# Altium schematic sheet PD_Controller_TI.SchDoc(PD_Controller_TI)
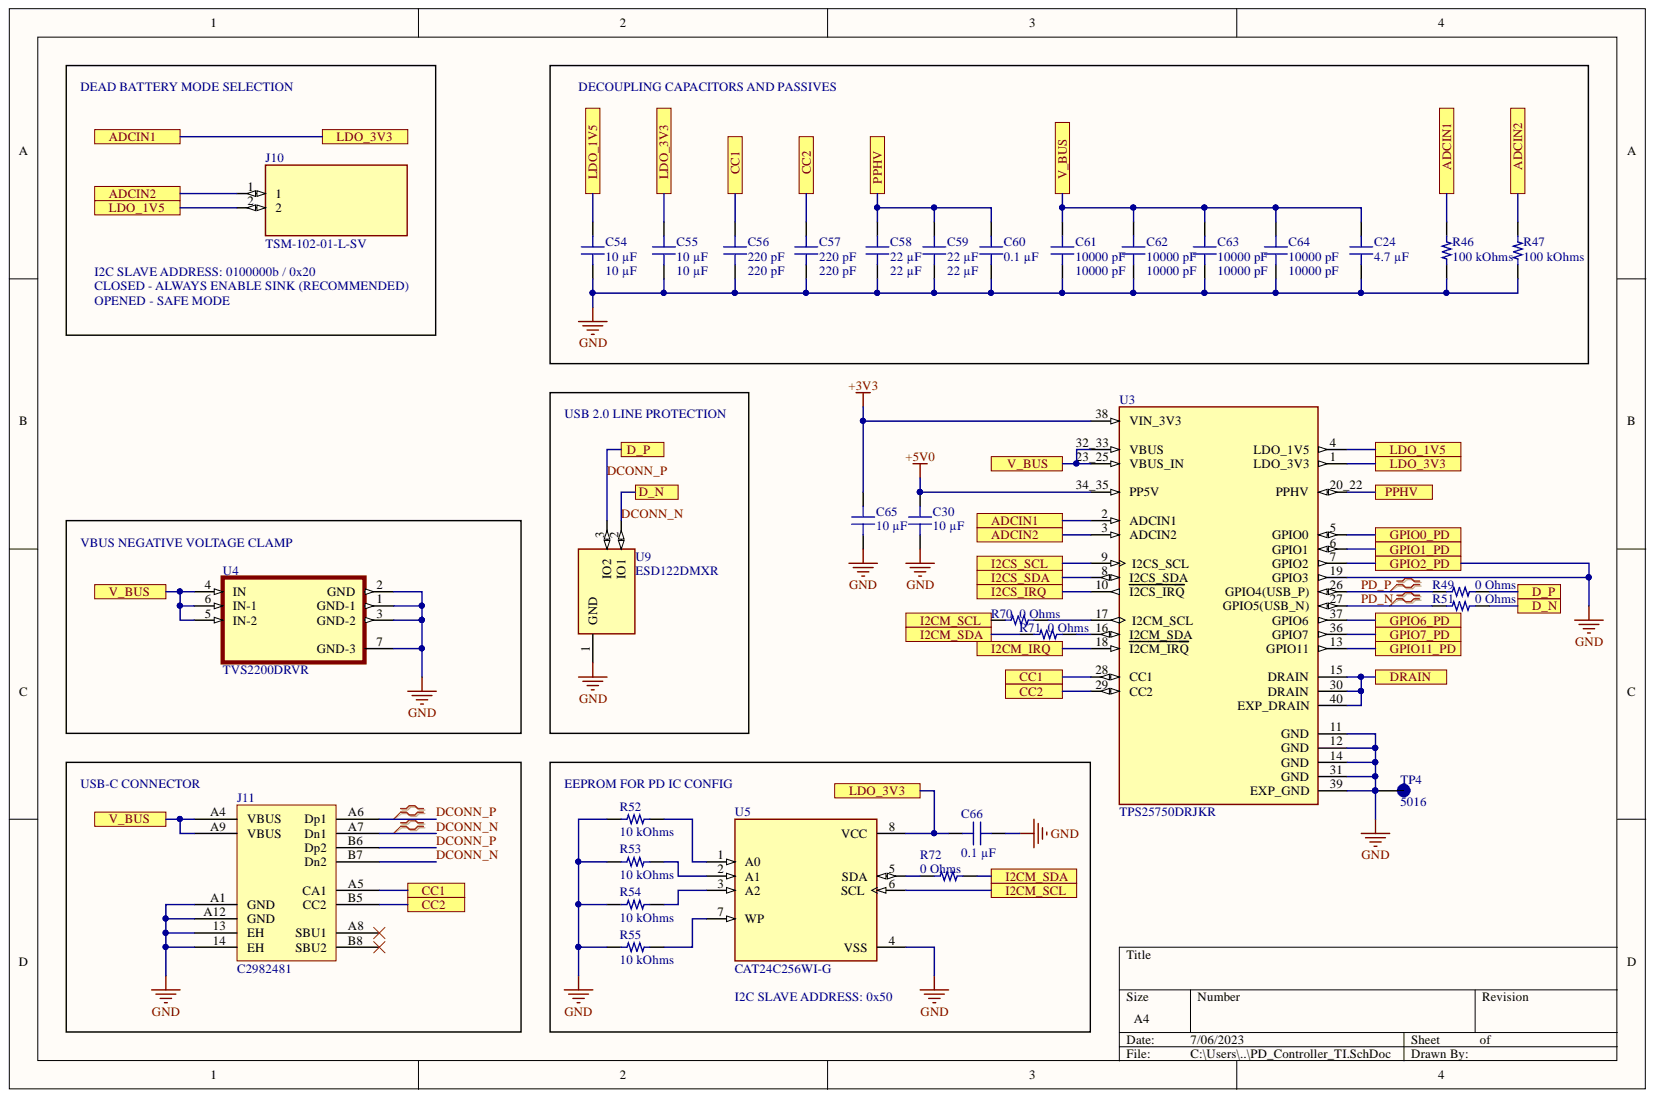
[diagram p0: PD_Controller_TI.SchDoc]

## Parts
C24  4.7 µF  [CAP 0603_1608]
C30  10 µF  [CAP 0402_1005]
C54  10 µF  [CAP 0402_1005]
C55  10 µF  [CAP 0402_1005]
C56  220 pF  [CAP 0402_1005]
C57  220 pF  [CAP 0402_1005]
C58  22 µF  [CAP 0805_2012]
C59  22 µF  [CAP 0805_2012]
C60  0.1 µF  [CAP 0402_1005]
C61  10000 pF  [CAP 0402_1005]
C62  10000 pF  [CAP 0402_1005]
C63  10000 pF  [CAP 0402_1005]
C64  10000 pF  [CAP 0402_1005]
C65  10 µF  [CAP 0402_1005]
C66  0.1 µF  [CAP 0402_1005]
J10  TSM-102-01-L-SV  [TSM-102-01-L-SV]
J11  C2982481  [GT-USB-7079A]
R46  100 kOhms  [RES 0402_1005]
R47  100 kOhms  [RES 0402_1005]
R49  0 Ohms  [RES 0402_1005]
R51  0 Ohms  [RES 0402_1005]
R52  10 kOhms  [RES 0402_1005]
R53  10 kOhms  [RES 0402_1005]
R54  10 kOhms  [RES 0402_1005]
R55  10 kOhms  [RES 0402_1005]
R70  0 Ohms  [RES 0402_1005]
R71  0 Ohms  [RES 0402_1005]
R72  0 Ohms  [RES 0402_1005]
TP4  5016  [KEYSTONE_5016]
U3  TPS25750DRJKR  [IC_TPS25750DRJKR]
U4  TVS2200DRVR  [SON65P200X200X80-7N]
U5  CAT24C256WI-G  [SOIC127P600X175-8N]
U9  ESD122DMXR  [IC_ESD122DMXR]

## Ports
ADCIN1, ADCIN2, CC1, CC2, D_N, D_P, DRAIN, GPIO0_PD, GPIO1_PD, GPIO2_PD, GPIO6_PD, GPIO7_PD, GPIO11_PD, I2CM_IRQ, I2CM_SCL, I2CM_SDA, I2CS_IRQ, I2CS_SCL, I2CS_SDA, LDO_1V5, LDO_3V3, PPHV, V_BUS

## Nets
+3V3: C65.1 U3.38(VIN_3V3)
+5V0: C30.1 U3.34_35(PP5V)
ADCIN1: C55.2 C66.2 R46.2 U3.1(LDO_3V3) U3.2(ADCIN1) U5.8(VCC) ; port ADCIN1, port LDO_3V3
ADCIN2: J10.1 R47.2 U3.3(ADCIN2) ; port ADCIN2
CC1: C56.1 J11.A5(CA1) U3.28(CC1) ; port CC1
CC2: C57.1 J11.B5(CC2) U3.29(CC2) ; port CC2
DCONN_N: J11.A7(Dn1) J11.B7(Dn2) R51.2 U9.2 ; port D_N
DCONN_P: J11.A6(Dp1) J11.B6(Dp2) R49.2 U9.3 ; port D_P
DRAIN: U3.15(DRAIN) U3.30(DRAIN) U3.40(EXP_DRAIN) ; port DRAIN
GND: C24.1 C30.2 C54.1 C55.1 C56.2 C57.2 C58.1 C59.1 C60.2 C61.1 C62.1 C63.1 C64.1 C65.2 C66.1 J11.13(EH) J11.14(EH) J11.A1(GND) J11.A12(GND) R46.1 R47.1 R52.2 R53.2 R54.2 R55.2 TP4.TP U3.7(GPIO2) U3.11(GND) U3.12(GND) U3.14(GND) U3.19(GPIO3) U3.31(GND) U3.39(EXP_GND) U4.1(GND-1) U4.2(GND) U4.3(GND-2) U4.7(GND-3) U5.4(VSS) U9.1 ; port GPIO2_PD
GPIO0_PD: U3.5(GPIO0) ; port GPIO0_PD
GPIO1_PD: U3.6(GPIO1) ; port GPIO1_PD
GPIO6_PD: U3.37(GPIO6) ; port GPIO6_PD
GPIO7_PD: U3.36(GPIO7) ; port GPIO7_PD
GPIO11_PD: U3.13(GPIO11) ; port GPIO11_PD
I2CM_IRQ: U3.18(I2CM_IRQ) ; port I2CM_IRQ
I2CM_SCL: R70.1 U5.6(SCL) ; port I2CM_SCL
I2CM_SDA: R71.1 R72.2 ; port I2CM_SDA
I2CS_IRQ: U3.10(I2CS_IRQ) ; port I2CS_IRQ
I2CS_SCL: U3.9(I2CS_SCL) ; port I2CS_SCL
I2CS_SDA: U3.8(I2CS_SDA) ; port I2CS_SDA
LDO_1V5: C54.2 J10.2 U3.4(LDO_1V5) ; port LDO_1V5
PD_N: R51.1 U3.27(GPIO5(USB_N))
PD_P: R49.1 U3.26(GPIO4(USB_P))
PPHV: C58.2 C59.2 C60.1 U3.20_22(PPHV) ; port PPHV
V_BUS: C24.2 C61.2 C62.2 C63.2 C64.2 J11.A4(VBUS) J11.A9(VBUS) U3.23_25(VBUS_IN) U3.32_33(VBUS) U4.4(IN) U4.5(IN-2) U4.6(IN-1) ; port V_BUS
NetR52_1: R52.1 U5.1(A0)
NetR53_1: R53.1 U5.2(A1)
NetR54_1: R54.1 U5.3(A2)
NetR55_1: R55.1 U5.7(WP)
NetR70_2: R70.2 U3.17(I2CM_SCL)
NetR71_2: R71.2 U3.16(I2CM_SDA)
NetR72_1: R72.1 U5.5(SDA)

Not connected: J11 A8(SBU1) B8(SBU2)

## Library data of the parts
C24 = C1608X5R1V475K080AC: CAP CER 4.7UF 35V X5R 0603; manufacturer: TDK Corporation; Tolerance: ±10%; Database Table Name: Capacitors - Ceramic - 0603; Voltage - Rated: 35V; Value: 4.7 µF; Thickness (Max): 0.039" (1.00mm); Temperature Coefficient: X5R; Supplier Part Number 1: 445-9064-1-ND; Supplier 1: DigiKey; Size / Dimension: 0.063" L x 0.031" W (1.60mm x 0.80mm); series: C; price: 0.08; Part Status: Active; Part Number: C1608X5R1V475K080AC; partid: 2627; packaging: TapeAndReel; Package / Case: 0603 (1608 Metric); Operating Temperature: -55°C ~ 85°C; Mounting Type: Surface Mount, MLCC; Minimum Order: 1; Lifecycle Status: Active; Library Name: Celestial Altium Library - altium_library.DbLib; lastupdated: 9/05/2023 7:14:01 PM; Features: Low ESL; Device Package: 0603 (1608 Metric); componentlink2url: https://www.digikey.com/en/products/detail/tdk-corporation/C1608X5R1V475K080AC/3648577; componentlink2description: DigiKey Link; componentlink1url: https://product.tdk.com/info/en/catalog/datasheets/mlcc_commercial_general_en.pdf?ref_disty=digikey; componentlink1description: Datasheet; Component Kind: Standard; Capacitance: 4.7 µF; Applications: General Purpose
C30 C54 C55 C65 = CL05A106MP8NUB8: CAP CER 10UF 10V X5R 0402; manufacturer: Samsung Electro-Mechanics; Tolerance: ±20%; Database Table Name: Capacitors - Ceramic - 0402; Voltage - Rated: 10V; Value: 10 µF; Thickness (Max): 0.035" (0.90mm); Temperature Coefficient: X5R; Supplier Part Number 1: 1276-6830-1-ND; Supplier 1: DigiKey; Size / Dimension: 0.039" L x 0.020" W (1.00mm x 0.50mm); series: CL; price: 0.06; Part Status: Active; Part Number: CL05A106MP8NUB8; partid: 1758; packaging: TapeAndReel; Package / Case: 0402 (1005 Metric); Operating Temperature: -55°C ~ 85°C; Mounting Type: Surface Mount, MLCC; Minimum Order: 1; Lifecycle Status: Active; Library Name: Celestial Altium Library - altium_library.DbLib; lastupdated: 26/04/2023 7:02:37 PM; Device Package: 0402 (1005 Metric); componentlink2url: https://www.digikey.com/en/products/detail/samsung-electro-mechanics/CL05A106MP8NUB8/5961314; componentlink2description: DigiKey Link; componentlink1url: http://www.samsungsem.com/kr/support/product-search/mlcc/CL05A106MP8NUB8.jsp; componentlink1description: Datasheet; Component Kind: Standard; Capacitance: 10 µF; Applications: Acoustic Noise Reduction
C56 C57 = 885012205021: CAP CER 220PF 16V X7R 0402; manufacturer: Würth Elektronik; Tolerance: ±10%; Database Table Name: Capacitors - Ceramic - 0402; Voltage - Rated: 16V; Value: 220 pF; Thickness (Max): 0.022" (0.55mm); Temperature Coefficient: X7R; Supplier Part Number 1: 732-7516-1-ND; Supplier 1: DigiKey; Size / Dimension: 0.039" L x 0.020" W (1.00mm x 0.50mm); series: WCAP-CSGP; price: 0.01; Part Status: Active; Part Number: 885012205021; partid: 2015; packaging: TapeAndReel; Package / Case: 0402 (1005 Metric); Operating Temperature: -55°C ~ 125°C; Mounting Type: Surface Mount, MLCC; Minimum Order: 1; Lifecycle Status: Active; Library Name: Celestial Altium Library - altium_library.DbLib; lastupdated: 26/04/2023 7:02:36 PM; Device Package: 0402 (1005 Metric); componentlink2url: https://www.digikey.com/en/products/detail/würth-elektronik/885012205021/5453371; componentlink2description: DigiKey Link; componentlink1url: https://katalog.we-online.de/pbs/datasheet/885012205021.pdf; componentlink1description: Datasheet; Component Kind: Standard; Capacitance: 220 pF; Applications: General Purpose
C58 C59 = C2012X5R1V226M125AC: CAP CER 22UF 35V X5R 0805; manufacturer: TDK Corporation; Tolerance: ±20%; Database Table Name: Capacitors - Ceramic - 0805; Voltage - Rated: 35V; Value: 22 µF; Thickness (Max): 0.057" (1.45mm); Temperature Coefficient: X5R; Supplier Part Number 1: 445-14428-1-ND; Supplier 1: DigiKey; Size / Dimension: 0.079" L x 0.049" W (2.00mm x 1.25mm); series: C; price: 0.28; Part Status: Active; Part Number: C2012X5R1V226M125AC; partid: 45365; packaging: TapeAndReel; Package / Case: 0805 (2012 Metric); Operating Temperature: -55°C ~ 85°C; Mounting Type: Surface Mount, MLCC; Minimum Order: 1; Lifecycle Status: Active; Library Name: Celestial Altium Library - altium_library.DbLib; lastupdated: 26/04/2023 7:09:36 PM; Features: Low ESL; Device Package: 0805 (2012 Metric); componentlink2url: https://www.digikey.com/en/products/detail/tdk-corporation/C2012X5R1V226M125AC/3951664; componentlink2description: DigiKey Link; componentlink1url: https://product.tdk.com/info/en/catalog/datasheets/mlcc_commercial_general_en.pdf?ref_disty=digikey; componentlink1description: Datasheet; Component Kind: Standard; Capacitance: 22 µF; Applications: General Purpose
C60 C66 = 885012105001: CAP CER 0.1UF 6.3V X5R 0402; manufacturer: Würth Elektronik; Tolerance: ±20%; Database Table Name: Capacitors - Ceramic - 0402; Voltage - Rated: 6.3V; Value: 0.1 µF; Thickness (Max): 0.022" (0.55mm); Temperature Coefficient: X5R; Supplier Part Number 1: 732-7478-1-ND; Supplier 1: DigiKey; Size / Dimension: 0.039" L x 0.020" W (1.00mm x 0.50mm); series: WCAP-CSGP; price: 0.01; Part Status: Active; Part Number: 885012105001; partid: 1931; packaging: TapeAndReel; Package / Case: 0402 (1005 Metric); Operating Temperature: -55°C ~ 85°C; Mounting Type: Surface Mount, MLCC; Minimum Order: 1; Lifecycle Status: Active; Library Name: Celestial Altium Library - altium_library.DbLib; lastupdated: 26/04/2023 7:02:37 PM; Device Package: 0402 (1005 Metric); componentlink2url: https://www.digikey.com/en/products/detail/würth-elektronik/885012105001/5453333; componentlink2description: DigiKey Link; componentlink1url: https://katalog.we-online.de/pbs/datasheet/885012105001.pdf; componentlink1description: Datasheet; Component Kind: Standard; Capacitance: 0.1 µF; Applications: General Purpose
C61-C64 = CGA2B3X7R1V103M050BB: CAP CER 10000PF 35V X7R 0402; manufacturer: TDK Corporation; Tolerance: ±20%; Database Table Name: Capacitors - Ceramic - 0402; Voltage - Rated: 35V; Value: 10000 pF; Thickness (Max): 0.022" (0.55mm); Temperature Coefficient: X7R; Supplier Part Number 1: 445-12253-1-ND; Supplier 1: DigiKey; Size / Dimension: 0.039" L x 0.020" W (1.00mm x 0.50mm); series: CGA; Ratings: AEC-Q200; price: 0.01; Part Status: Active; Part Number: CGA2B3X7R1V103M050BB; partid: 1926; packaging: TapeAndReel; Package / Case: 0402 (1005 Metric); Operating Temperature: -55°C ~ 125°C; Mounting Type: Surface Mount, MLCC; Minimum Order: 1; Lifecycle Status: Active; Library Name: Celestial Altium Library - altium_library.DbLib; lastupdated: 26/04/2023 7:02:37 PM; Device Package: 0402 (1005 Metric); componentlink2url: https://www.digikey.com/en/products/detail/tdk-corporation/CGA2B3X7R1V103M050BB/3949489; componentlink2description: DigiKey Link; componentlink1url: https://product.tdk.com/info/en/catalog/datasheets/mlcc_automotive_general_en.pdf?ref_disty=digikey; componentlink1description: Datasheet; Component Kind: Standard; Capacitance: 10000 pF; Applications: Automotive
J10 = TSM-102-01-L-SV: MF: {'manufacturer': u'Samtec Inc.'}; Price: None; Package: None; MP: TSM-102-01-L-SV; Component Kind: Standard; Availability: Unavailable
J11 = GT-USB-7079A: 5A 1 Surface Mount 16 Female -55℃~+85℃ Type-C SMD USB Connectors ROHS C2982481; Library Name: Schlib2.SchLib; Component Kind: Standard
R46 R47 = RT0402FRE07100KL: RES SMD 100K OHM 1% 1/16W 0402; Manufacturer: Yageo; Temperature Coefficient: ±50ppm/°C; Power (Watts): 0.063W, 1/16W; Database Table Name: Resistors - Surface Mount - 0402; Value: 100 kOhms; Tolerance: ±1%; Supplier Part Number 1: YAG2307CT-ND; Supplier Device Package: 0402; Supplier 1: DigiKey; Size / Dimension: 0.039" L x 0.020" W (1.00mm x 0.50mm); Series: RT; Resistance: 100 kOhms; Price: 0.01; Part Status: Active; Part Number: RT0402FRE07100KL; PartId: 3190; Packaging: TapeAndReel; Package / Case: 0402 (1005 Metric); Operating Temperature: -55°C ~ 155°C; Number of Terminations: 2; Minimum Order: 1; Lifecycle Status: Active; Library Name: Celestial Altium Library - altium_library.DbLib; LastUpdated: 26/04/2023 7:20:40 PM; Height - Seated (Max): 0.014" (0.35mm); Device Package: 0402 (1005 Metric); Composition: Thin Film; ComponentLink2URL: https://www.digikey.com/en/products/detail/yageo/RT0402FRE07100KL/1071698; ComponentLink2Description: DigiKey Link; ComponentLink1URL: https://www.yageo.com/upload/media/product/productsearch/datasheet/rchip/PYu-RT_1-to-0.01_RoHS_L_11.pdf; ComponentLink1Description: Datasheet; Component Kind: Standard
R49 R51 R70-R72 = CRCW04020000Z0EDHP: RES SMD 0 OHM JUMPER 1/5W 0402; Manufacturer: Vishay Dale; Power (Watts): 0.2W, 1/5W; Database Table Name: Resistors - Surface Mount - 0402; Value: 0 Ohms; Tolerance: Jumper; Supplier Part Number 1: 541-0.0YBCT-ND; Supplier Device Package: 0402; Supplier 1: DigiKey; Size / Dimension: 0.039" L x 0.020" W (1.00mm x 0.50mm); Series: CRCW-HP; Resistance: 0 Ohms; Ratings: AEC-Q200; Price: 0.01; Part Status: Active; Part Number: CRCW04020000Z0EDHP; PartId: 3131; Packaging: TapeAndReel; Package / Case: 0402 (1005 Metric); Operating Temperature: -55°C ~ 155°C; Number of Terminations: 2; Minimum Order: 1; Lifecycle Status: Active; Library Name: Celestial Altium Library - altium_library.DbLib; LastUpdated: 27/04/2023 7:26:03 PM; Height - Seated (Max): 0.016" (0.40mm); Features: Automotive AEC-Q200, Pulse Withstanding; Device Package: 0402 (1005 Metric); Composition: Thick Film; ComponentLink2URL: https://www.digikey.com/en/products/detail/vishay-dale/CRCW04020000Z0EDHP/2222496; ComponentLink2Description: DigiKey Link; ComponentLink1URL: http://www.vishay.com/docs/20043/crcwhpe3.pdf; ComponentLink1Description: Datasheet; Component Kind: Standard
R52-R55 = RC0402JR-1310KL: RES 10K OHM 5% 1/16W 0402; Manufacturer: Yageo; Temperature Coefficient: ±100ppm/°C; Power (Watts): 0.063W, 1/16W; Database Table Name: Resistors - Surface Mount - 0402; Value: 10 kOhms; Tolerance: ±5%; Supplier Part Number 1: 13-RC0402JR-1310KLTR-ND; Supplier Device Package: 0402; Supplier 1: DigiKey; Size / Dimension: 0.039" L x 0.020" W (1.00mm x 0.50mm); Series: RC_L; Resistance: 10 kOhms; Price: 0; Part Status: Active; Part Number: RC0402JR-1310KL; PartId: 69237; Package / Case: 0402 (1005 Metric); Operating Temperature: -55°C ~ 155°C; Number of Terminations: 2; Minimum Order: 50000; Lifecycle Status: Active; Library Name: Celestial Altium Library - altium_library.DbLib; LastUpdated: 4/04/2023 7:21:31 PM; Height - Seated (Max): 0.016" (0.40mm); Features: Moisture Resistant; Device Package: 0402 (1005 Metric); Composition: Thick Film; ComponentLink2URL: https://www.digikey.com/en/products/detail/yageo/RC0402JR-1310KL/13694115; ComponentLink2Description: DigiKey Link; ComponentLink1URL: https://www.yageo.com/upload/media/product/productsearch/datasheet/rchip/PYu-RC_Group_51_RoHS_L_11.pdf; ComponentLink1Description: Datasheet; Component Kind: Standard
TP4 = 5016: MF: {'manufacturer': u'Keystone Electronics'}; Price: None; Package: None; MP: 5016; Component Kind: Standard; Availability: Unavailable
U3 = TPS25750DRJKR: USB, Type-C Controller PMIC 38-WQFN (4x6); MF: {'manufacturer': u'Texas Instruments'}; Price: None; Package: None; MP: TPS25750DRJKR; Library Name: TPS25750DRJKR.SchLib; Component Kind: Standard; Availability: Unavailable
U4 = TVS2200DRVR: MF: {'manufacturer': u'Texas Instruments'}; Price: None; Package: None; MP: TVS2200DRVR; Component Kind: Standard; Availability: Unavailable
U5 = CAT24C256WI-G: EEPROM Memory IC 256Kb (32K x 8) IÂ²C 1MHz 500ns 8-SOIC; MF: {'manufacturer': u'ON Semiconductor'}; Price: None; Package: None; MP: CAT24C256WI-G; Component Kind: Standard; Availability: Unavailable
U9 = ESD122DMXR: 2-channel ESD protection diode for USB Type-C and HDMI 2.0 3-X2SON -40 to 125; MF: {'manufacturer': u'Texas Instruments'}; Price: None; Package: None; MP: ESD122DMXR; Component Kind: Standard; Availability: Unavailable
